# Altium schematic sheet Extension.SchDoc(Extension)
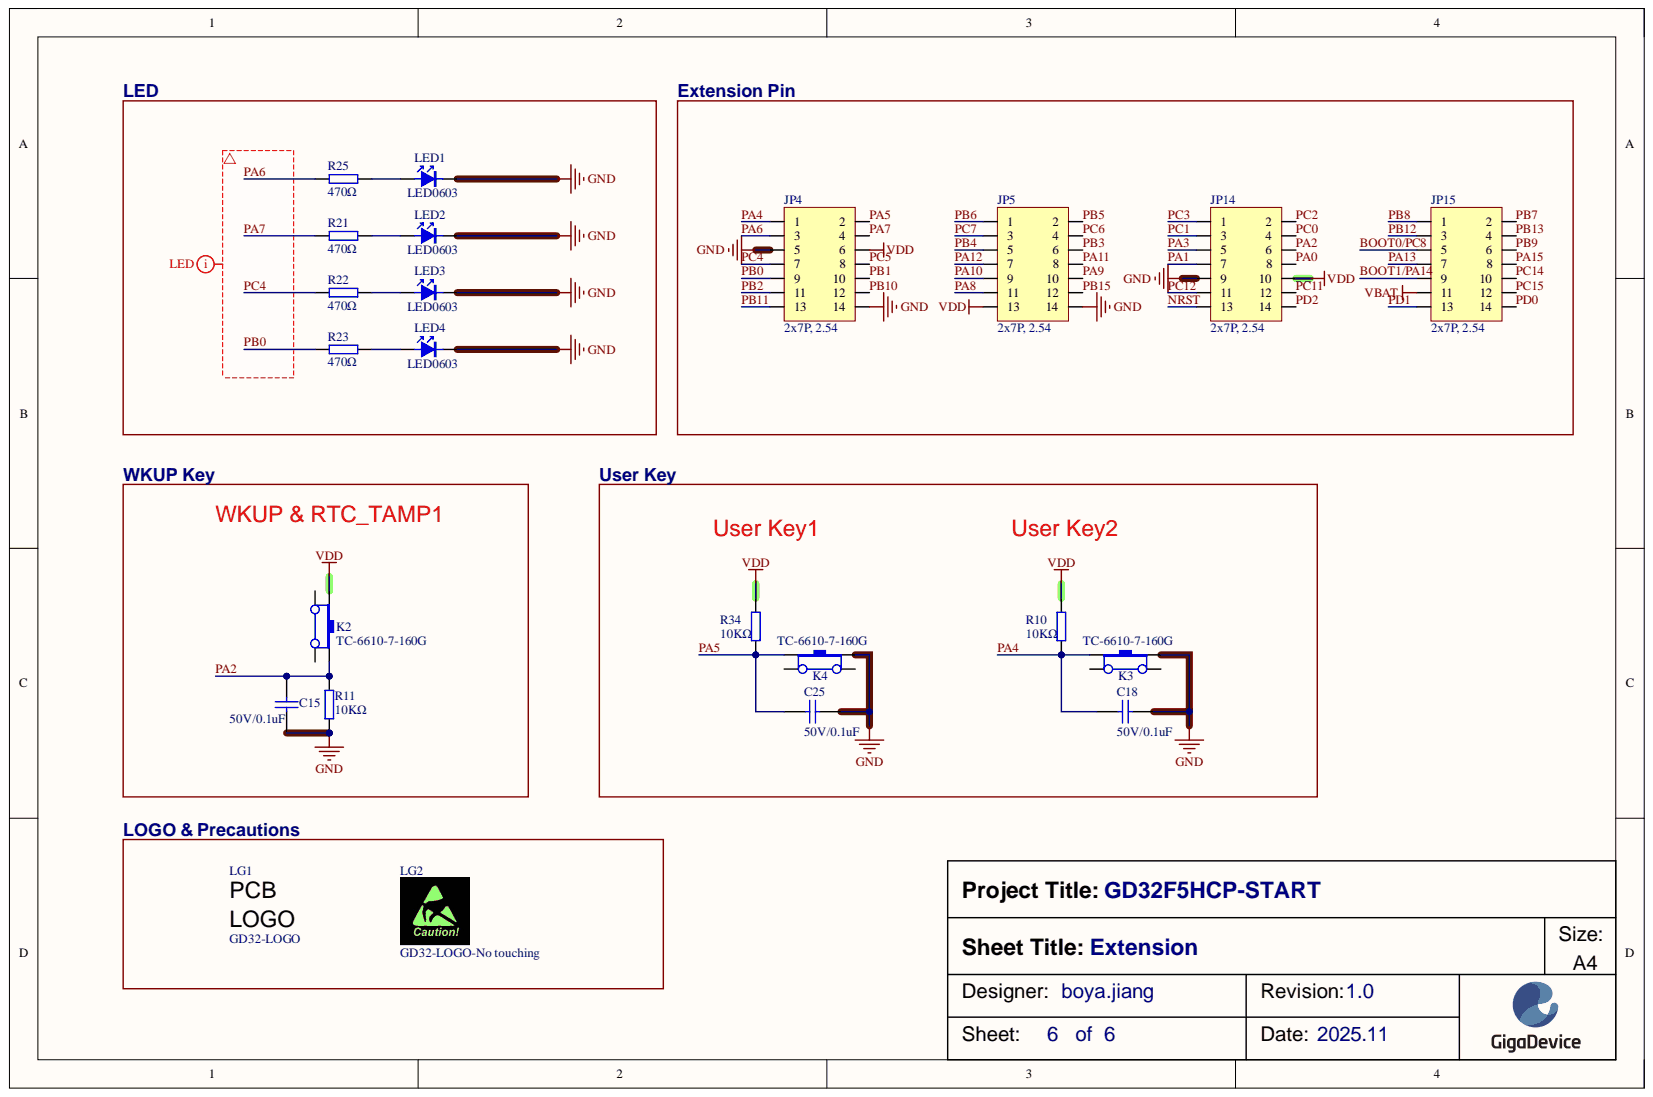
[diagram p0: Extension.SchDoc]

## Parts
C15  50V/0.1uF  [0603-C]
C18  50V/0.1uF  [0603-C]
C25  50V/0.1uF  [0603-C]
JP4  2x7P, 2.54  [IDC-14]
JP5  2x7P, 2.54  [IDC-14]
JP14  2x7P, 2.54  [IDC-14]
JP15  2x7P, 2.54  [IDC-14]
K2  TC-6610-7-160G  [K-1102B]
K3  TC-6610-7-160G  [K-1102B]
K4  TC-6610-7-160G  [K-1102B]
LED1  NCD0603C1(黄绿)  [0603-LED-Green]  (value not drawn)
LED2  NCD0603C1(黄绿)  [0603-LED-Green]  (value not drawn)
LED3  NCD0603C1(黄绿)  [0603-LED-Green]  (value not drawn)
LED4  NCD0603C1(黄绿)  [0603-LED-Green]  (value not drawn)
LG1  GD32-LOGO  [GD32-LOGO-Small]  (no BOM)
LG2  GD32-LOGO-No touching  [NO TOUCH-Medium]  (no BOM)
R10  10KΩ  [0603-R]
R11  10KΩ  [0603-R]
R21  470Ω  [0603-R]
R22  470Ω  [0603-R]
R23  470Ω  [0603-R]
R25  470Ω  [0603-R]
R34  10KΩ  [0603-R]

## Nets
GND: C15.1 C18.2 C25.2 JP4.5 JP4.14 JP5.14 JP14.9 K3.3 K4.3 LED1.K LED2.K LED3.K LED4.K R11.2
VBAT: JP15.11
VDD: JP4.6 JP5.13 JP14.10 K2.1 R10.1 R34.1
BOOT0/PC8: JP15.5
BOOT1/PA14: JP15.9
NRST: JP14.13
PA0: JP14.8
PA1: JP14.7
PA2: C15.2 JP14.6 K2.3 R11.1
PA3: JP14.5
PA4: C18.1 JP4.1 K3.1 R10.2
PA5: C25.1 JP4.2 K4.1 R34.2
PA6: JP4.3 R25.1
PA7: JP4.4 R21.1
PA8: JP5.11
PA9: JP5.10
PA10: JP5.9
PA11: JP5.8
PA12: JP5.7
PA13: JP15.7
PA15: JP15.8
PB0: JP4.9 R23.1
PB1: JP4.10
PB2: JP4.11
PB3: JP5.6
PB4: JP5.5
PB5: JP5.2
PB6: JP5.1
PB7: JP15.2
PB8: JP15.1
PB9: JP15.6
PB10: JP4.12
PB11: JP4.13
PB12: JP15.3
PB13: JP15.4
PB15: JP5.12
PC0: JP14.4
PC1: JP14.3
PC2: JP14.2
PC3: JP14.1
PC4: JP4.7 R22.1
PC5: JP4.8
PC6: JP5.4
PC7: JP5.3
PC11: JP14.12
PC12: JP14.11
PC14: JP15.10
PC15: JP15.12
PD0: JP15.14
PD1: JP15.13
PD2: JP14.14
NetLED1_A: LED1.A R25.2
NetLED2_A: LED2.A R21.2
NetLED3_A: LED3.A R22.2
NetLED4_A: LED4.A R23.2

Not connected: K2 2 4; K3 2 4; K4 2 4

## Library data of the parts
C15 C18 C25 = 50V/0.1uF: 表贴电容; Component Kind: Standard; Library Name: KLTC-电容.SchLib
JP4 JP5 JP14 JP15 = HEADER 7x2: 双排插针; Note: 不焊; Component Kind: Standard; Library Name: boyajiang.SchLib
K2-K4 = K-1102B: 直插6*6*7mm轻触按键开关, 单刀单掷, 1.6N; Component Kind: Standard; Library Name: KLTC-按键及接插件.SchLib
LED1-LED4 = LED0603: 表贴LED发光管; Component Kind: Standard; Footprint For: LED0603; Library Name: xufei.SchLib
LG1 = GD32-LOGO: GD图标; Database Table Name: GD-Assembly&Silkscreen; ID: GD32-LOGO; Library Name: SVNDatabase.SVNDbLib; Value: ?
LG2 = GD32-LOGO-No touching: 禁止触摸图标; Database Table Name: GD-Assembly&Silkscreen; ID: GD32-LOGO-No touching; Library Name: SVNDatabase.SVNDbLib; Value: ?
R10 R11 R34 = 10KΩ: 表贴电阻; Component Kind: Standard; Library Name: KLTC-电阻.SchLib
R21-R23 R25 = 470Ω: 表贴电阻; Component Kind: Standard; Library Name: KLTC-电阻.SchLib
